# Altium schematic sheet ESP32.SchDoc(ESP32)
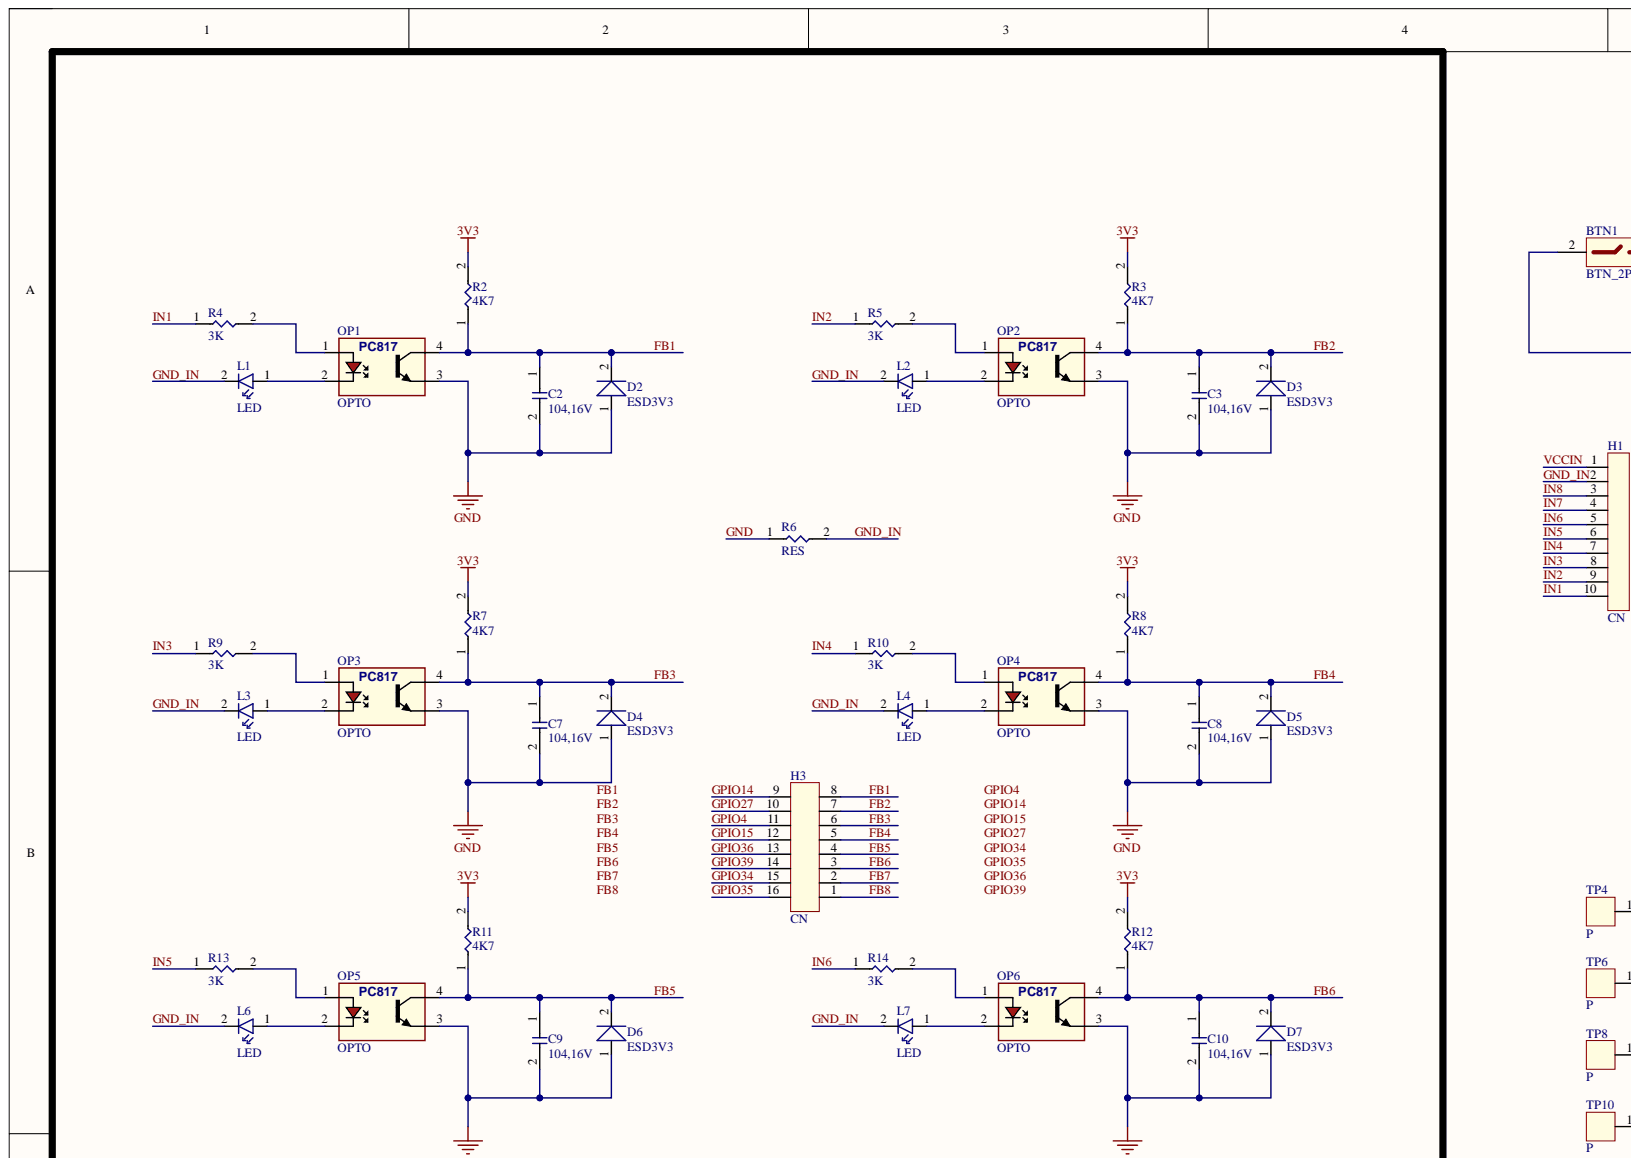
[diagram p0: ESP32.SchDoc, top left]
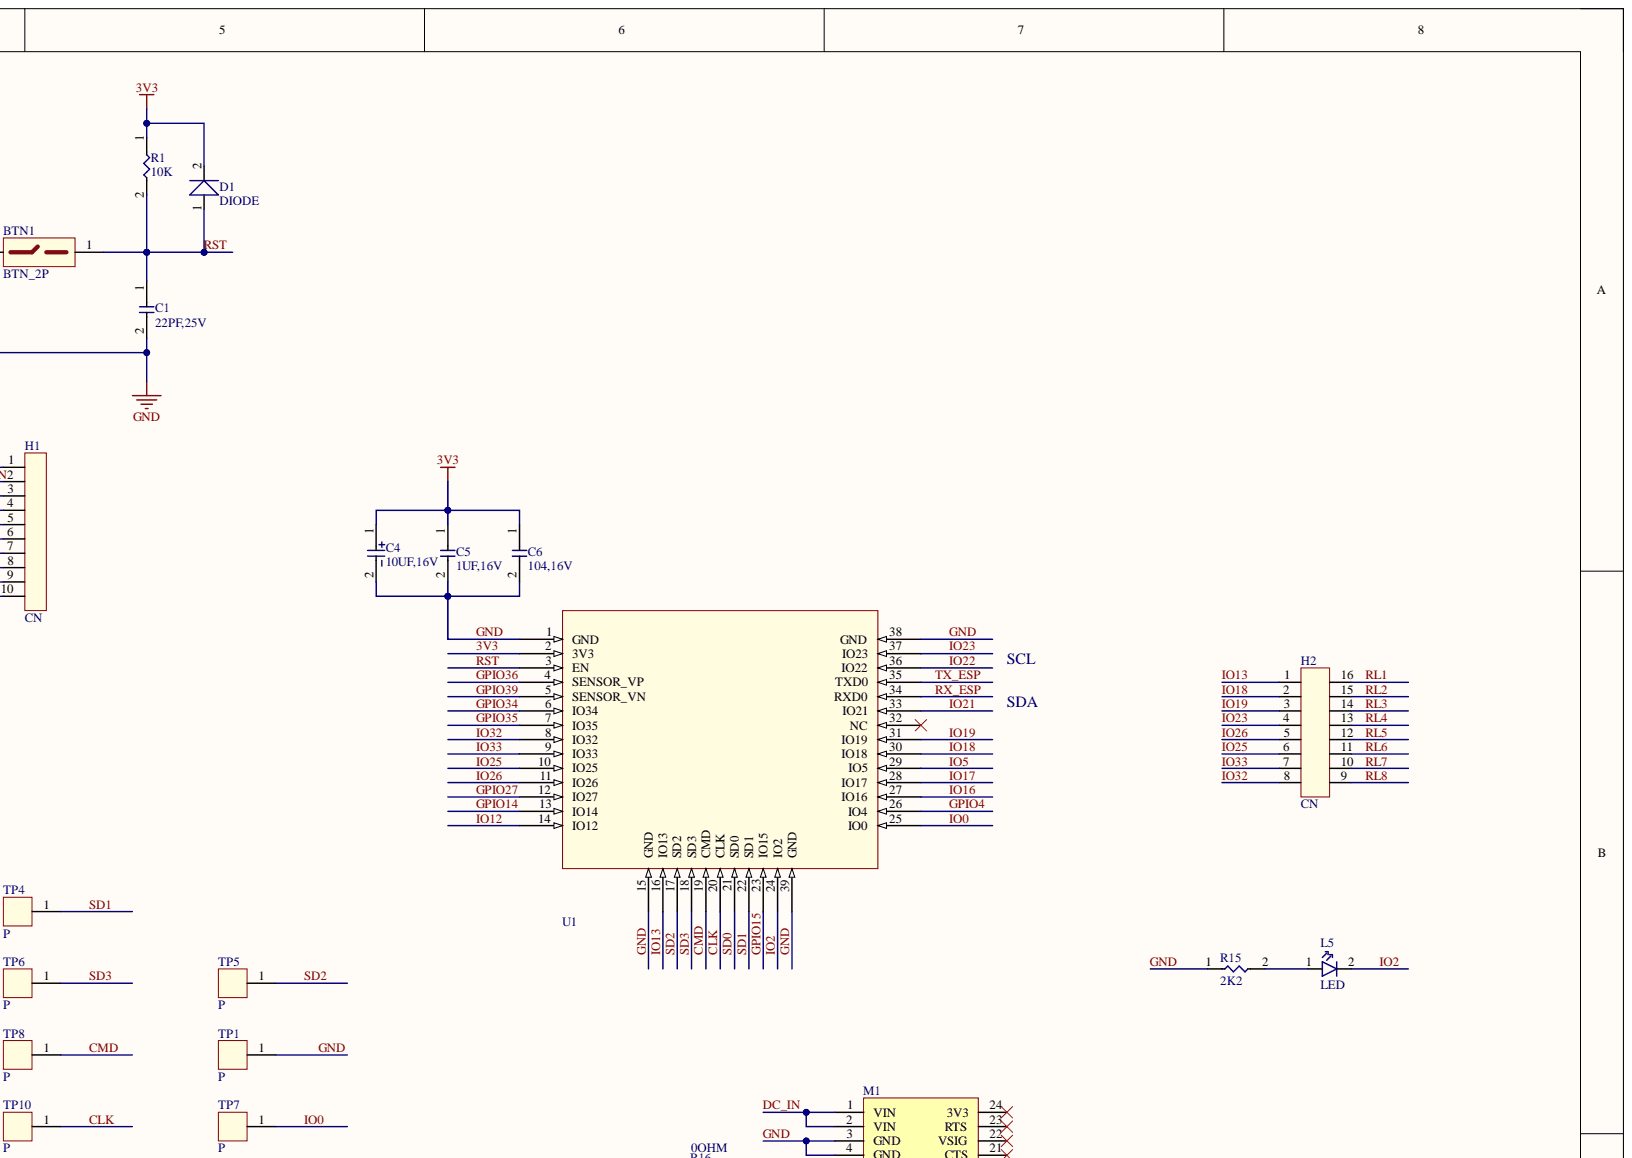
[diagram p1: ESP32.SchDoc, top right]
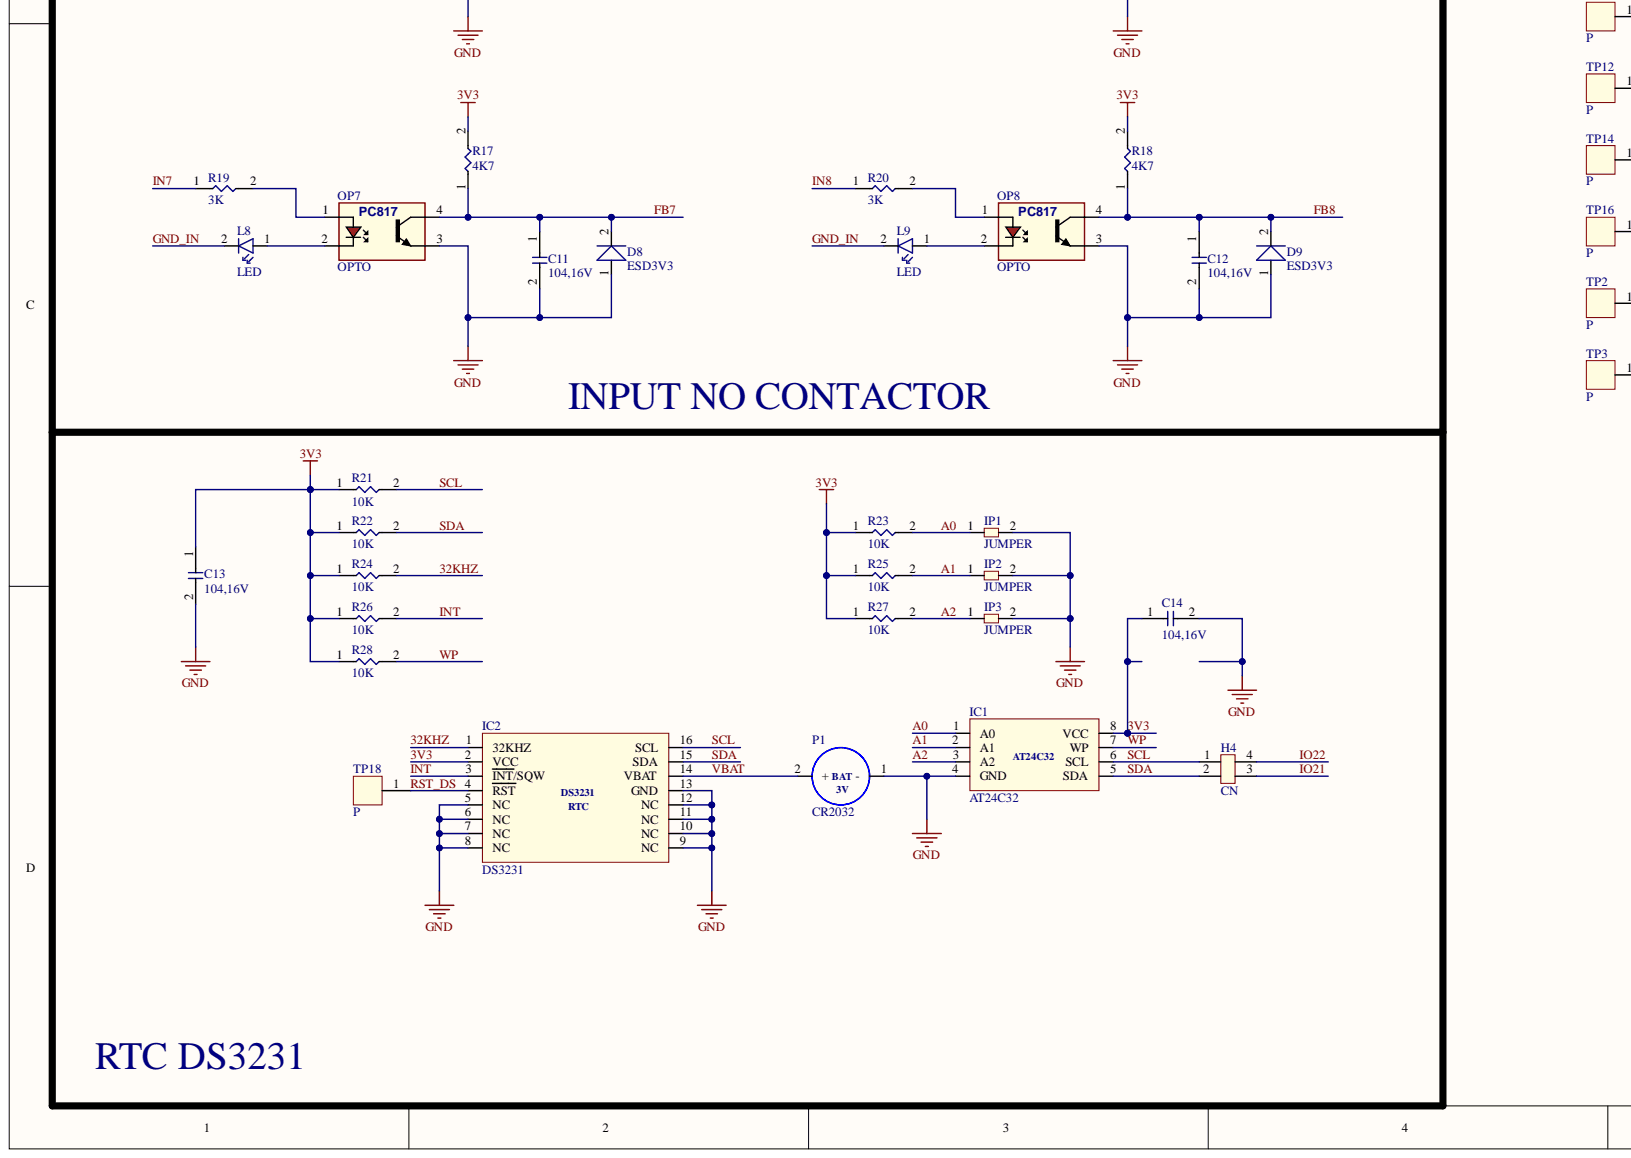
[diagram p2: ESP32.SchDoc, bottom left]
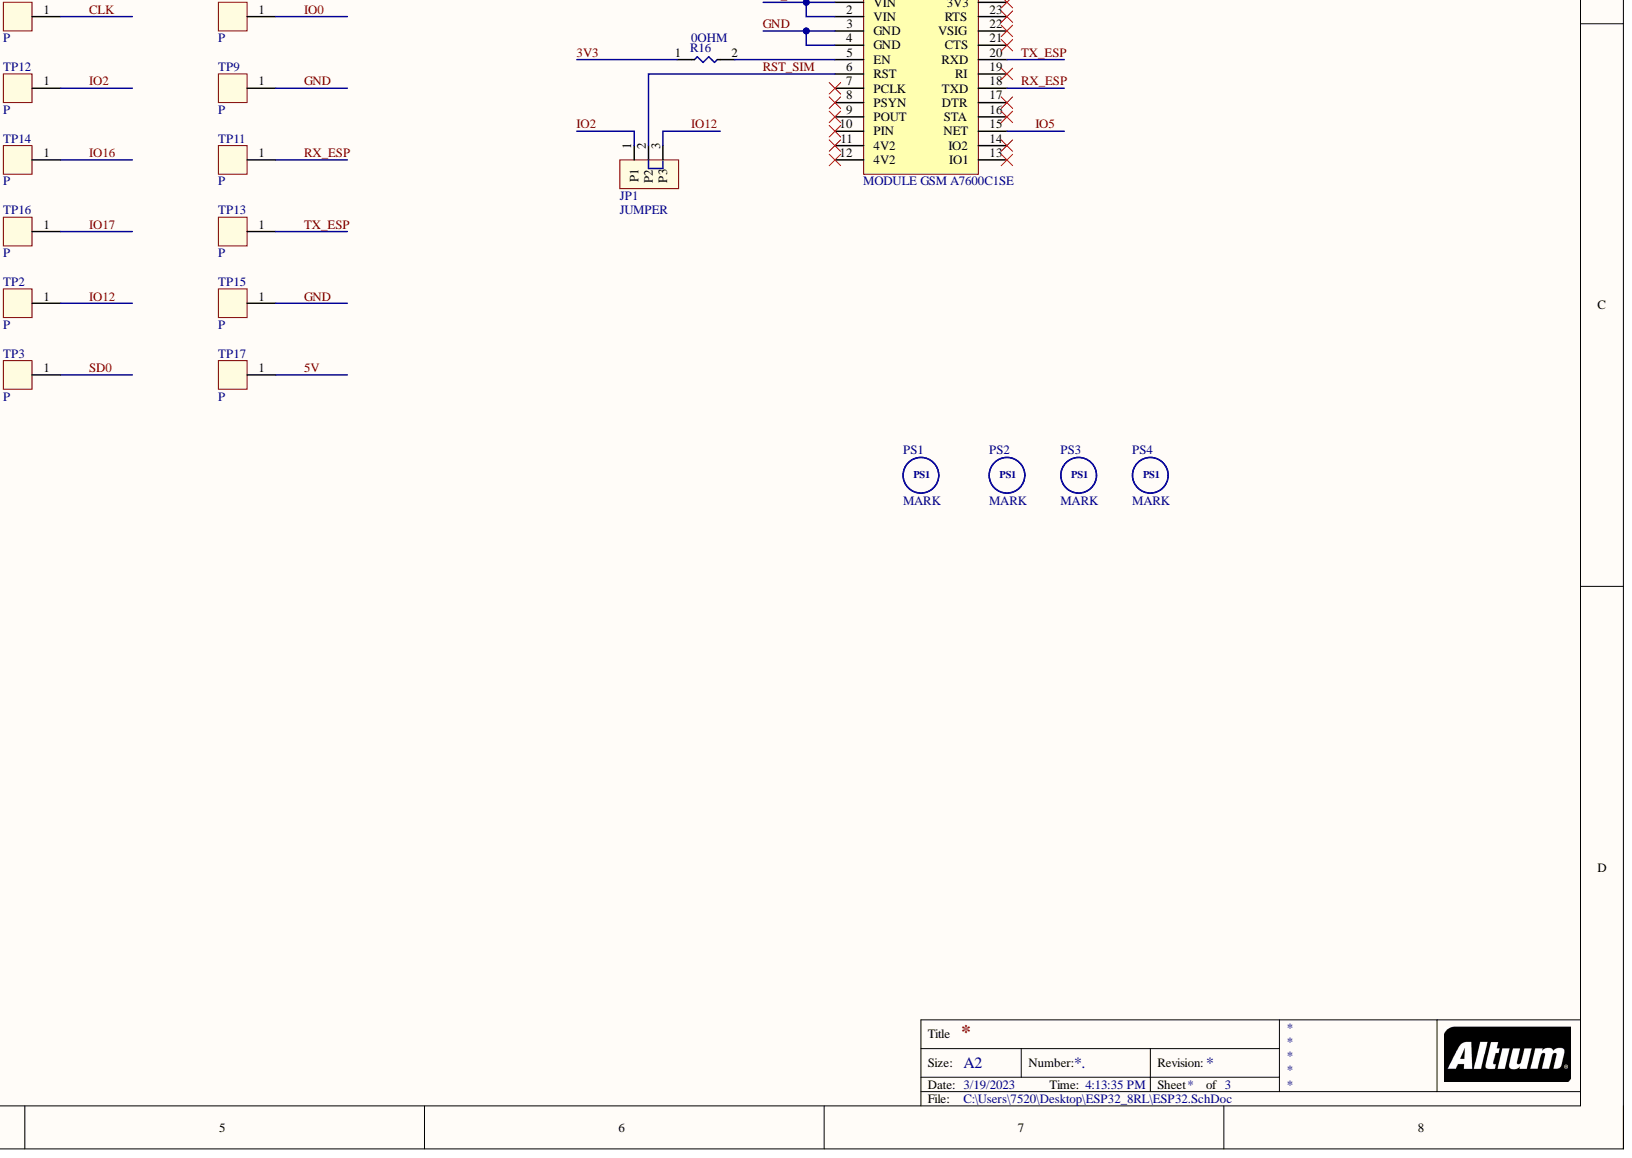
[diagram p3: ESP32.SchDoc, bottom right]

## Parts
BTN1  BTN_2P  [BTN_80X60X35]
C1  22PF,25V  [cap_smd_0603]
C2  104,16V  [cap_smd_0603]
C3  104,16V  [cap_smd_0603]
C4  10UF,16V  [CAP_TAN_B]
C5  1UF,16V  [cap_smd_0603]
C6  104,16V  [cap_smd_0603]
C7  104,16V  [cap_smd_0603]
C8  104,16V  [cap_smd_0603]
C9  104,16V  [cap_smd_0603]
C10  104,16V  [cap_smd_0603]
C11  104,16V  [cap_smd_0603]
C12  104,16V  [cap_smd_0603]
C13  104,16V  [cap_smd_0603]
C14  104,16V  [cap_smd_0603]
D1  DIODE  [DIODE_SMD_SOD_323]
D2  ESD3V3  [DIODE_SMD_SOD_323]
D3  ESD3V3  [DIODE_SMD_SOD_323]
D4  ESD3V3  [DIODE_SMD_SOD_323]
D5  ESD3V3  [DIODE_SMD_SOD_323]
D6  ESD3V3  [DIODE_SMD_SOD_323]
D7  ESD3V3  [DIODE_SMD_SOD_323]
D8  ESD3V3  [DIODE_SMD_SOD_323]
D9  ESD3V3  [DIODE_SMD_SOD_323]
H1  CN  [10P_35MM_TER]
H2  CN  [8X02P_T]
H3  CN  [8X02P_T]
H4  CN  [2X02P_T]
IC1  AT24C32  [AT24C32]
IC2  DS3231  [DS3231]
IP1  JUMPER  [JP]
IP2  JUMPER  [JP]
IP3  JUMPER  [JP]
JP1  JUMPER  [jp3_0603]
L1  LED  [led_0603_blue]
L2  LED  [led_0603_blue]
L3  LED  [led_0603_blue]
L4  LED  [led_0603_blue]
L5  LED  [LED_3MM_DIP]
L6  LED  [led_0603_blue]
L7  LED  [led_0603_blue]
L8  LED  [led_0603_blue]
L9  LED  [led_0603_blue]
M1  MODULE GSM A7600C1SE  [A7600C1SE]
OP1  OPTO  [PC817_SMD]
OP2  OPTO  [PC817_SMD]
OP3  OPTO  [PC817_SMD]
OP4  OPTO  [PC817_SMD]
OP5  OPTO  [PC817_SMD]
OP6  OPTO  [PC817_SMD]
OP7  OPTO  [PC817_SMD]
OP8  OPTO  [PC817_SMD]
P1  CR2032  [CR1220]
PS1  MARK  [ps1]
PS2  MARK  [ps1]
PS3  MARK  [ps1]
PS4  MARK  [ps1]
R1  10K  [0603_R_L]
R2  4K7  [0603_R_L]
R3  4K7  [0603_R_L]
R4  3K  [0805_R_L]
R5  3K  [0805_R_L]
R6  RES  [0805_R_L]
R7  4K7  [0603_R_L]
R8  4K7  [0603_R_L]
R9  3K  [0805_R_L]
R10  3K  [0805_R_L]
R11  4K7  [0603_R_L]
R12  4K7  [0603_R_L]
R13  3K  [0805_R_L]
R14  3K  [0805_R_L]
R15  2K2  [0603_R_L]
R16  0OHM  [0603_R_L]
R17  4K7  [0603_R_L]
R18  4K7  [0603_R_L]
R19  3K  [0805_R_L]
R20  3K  [0805_R_L]
R21  10K  [0603_R_L]
R22  10K  [0603_R_L]
R23  10K  [0603_R_L]
R24  10K  [0603_R_L]
R25  10K  [0603_R_L]
R26  10K  [0603_R_L]
R27  10K  [0603_R_L]
R28  10K  [0603_R_L]
TP1  P  [TP]
TP2  P  [TP]
TP3  P  [TP]
TP4  P  [TP]
TP5  P  [TP]
TP6  P  [TP]
TP7  P  [TP]
TP8  P  [TP]
TP9  P  [TP]
TP10  P  [TP]
TP11  P  [TP]
TP12  P  [TP]
TP13  P  [TP]
TP14  P  [TP]
TP15  P  [TP]
TP16  P  [TP]
TP17  P  [TP]
TP18  P  [TP]
U1  ESP32-WROOM-32  [ESP32-WROOM-32D]  (value not drawn)

## Nets
3V3: C4.1 C5.1 C6.1 C13.1 C14.1 D1.2 IC1.8(VCC) IC2.2(VCC) R1.1 R2.2 R3.2 R7.2 R8.2 R11.2 R12.2 R16.1 R17.2 R18.2 R21.1 R22.1 R23.1 R24.1 R25.1 R26.1 R27.1 R28.1 U1.2(3V3)
5V: TP17.1
32KHZ: IC2.1(32KHZ) R24.2
A0: IC1.1(A0) IP1.1 R23.2
A1: IC1.2(A1) IP2.1 R25.2
A2: IC1.3(A2) IP3.1 R27.2
CLK: TP10.1 U1.20(CLK)
CMD: TP8.1 U1.19(CMD)
DC_IN: M1.1(VIN) M1.2(VIN)
FB1: C2.1 D2.2 H3.8 OP1.4 R2.1
FB2: C3.1 D3.2 H3.7 OP2.4 R3.1
FB3: C7.1 D4.2 H3.6 OP3.4 R7.1
FB4: C8.1 D5.2 H3.5 OP4.4 R8.1
FB5: C9.1 D6.2 H3.4 OP5.4 R11.1
FB6: C10.1 D7.2 H3.3 OP6.4 R12.1
FB7: C11.1 D8.2 H3.2 OP7.4 R17.1
FB8: C12.1 D9.2 H3.1 OP8.4 R18.1
GND: BTN1.2 C1.2 C2.2 C3.2 C4.2 C5.2 C6.2 C7.2 C8.2 C9.2 C10.2 C11.2 C12.2 C13.2 C14.2 D2.1 D3.1 D4.1 D5.1 D6.1 D7.1 D8.1 D9.1 IC1.4(GND) IC2.5(NC) IC2.6(NC) IC2.7(NC) IC2.8(NC) IC2.9(NC) IC2.10(NC) IC2.11(NC) IC2.12(NC) IC2.13(GND) IP1.2 IP2.2 IP3.2 M1.3(GND) M1.4(GND) OP1.3 OP2.3 OP3.3 OP4.3 OP5.3 OP6.3 OP7.3 OP8.3 P1.1 R6.1 R15.1 TP1.1 TP9.1 TP15.1 U1.1(GND) U1.15(GND) U1.38(GND) U1.39(GND)
GND_IN: H1.2 L1.2 L2.2 L3.2 L4.2 L6.2 L7.2 L8.2 L9.2 R6.2
GPIO4: H3.11 U1.26(IO4)
GPIO14: H3.9 U1.13(IO14)
GPIO15: H3.12 U1.23(IO15)
GPIO27: H3.10 U1.12(IO27)
GPIO34: H3.15 U1.6(IO34)
GPIO35: H3.16 U1.7(IO35)
GPIO36: H3.13 U1.4(SENSOR_VP)
GPIO39: H3.14 U1.5(SENSOR_VN)
IN1: H1.10 R4.1
IN2: H1.9 R5.1
IN3: H1.8 R9.1
IN4: H1.7 R10.1
IN5: H1.6 R13.1
IN6: H1.5 R14.1
IN7: H1.4 R19.1
IN8: H1.3 R20.1
INT: IC2.3(INT/SQW) R26.2
IO0: TP7.1 U1.25(IO0)
IO2: JP1.1 L5.2 TP12.1 U1.24(IO2)
IO5: M1.15(NET) U1.29(IO5)
IO12: JP1.3 TP2.1 U1.14(IO12)
IO13: H2.1 U1.16(IO13)
IO16: TP14.1 U1.27(IO16)
IO17: TP16.1 U1.28(IO17)
IO18: H2.2 U1.30(IO18)
IO19: H2.3 U1.31(IO19)
IO21: H4.3 U1.33(IO21)
IO22: H4.4 U1.36(IO22)
IO23: H2.4 U1.37(IO23)
IO25: H2.6 U1.10(IO25)
IO26: H2.5 U1.11(IO26)
IO32: H2.8 U1.8(IO32)
IO33: H2.7 U1.9(IO33)
RL1: H2.16
RL2: H2.15
RL3: H2.14
RL4: H2.13
RL5: H2.12
RL6: H2.11
RL7: H2.10
RL8: H2.9
RST: BTN1.1 C1.1 D1.1 R1.2 U1.3(EN)
RST_DS: IC2.4(RST) TP18.1
RST_SIM: JP1.2 M1.6(RST)
RX_ESP: M1.18(TXD) TP11.1 U1.34(RXD0)
SCL: H4.1 IC1.6(SCL) IC2.16(SCL) R21.2
SD0: TP3.1 U1.21(SD0)
SD1: TP4.1 U1.22(SD1)
SD2: TP5.1 U1.17(SD2)
SD3: TP6.1 U1.18(SD3)
SDA: H4.2 IC1.5(SDA) IC2.15(SDA) R22.2
TX_ESP: M1.20(RXD) TP13.1 U1.35(TXD0)
VBAT: IC2.14(VBAT) P1.2
VCCIN: H1.1
WP: IC1.7(WP) R28.2
NetL1_1: L1.1 OP1.2
NetL2_1: L2.1 OP2.2
NetL3_1: L3.1 OP3.2
NetL4_1: L4.1 OP4.2
NetL5_1: L5.1 R15.2
NetL6_1: L6.1 OP5.2
NetL7_1: L7.1 OP6.2
NetL8_1: L8.1 OP7.2
NetL9_1: L9.1 OP8.2
NetM1_5: M1.5(EN) R16.2
NetOP1_1: OP1.1(PC817) R4.2
NetOP2_1: OP2.1(PC817) R5.2
NetOP3_1: OP3.1(PC817) R9.2
NetOP4_1: OP4.1(PC817) R10.2
NetOP5_1: OP5.1 R13.2
NetOP6_1: OP6.1 R14.2
NetOP7_1: OP7.1 R19.2
NetOP8_1: OP8.1 R20.2

Not connected: M1 7(PCLK) 8(PSYN) 9(POUT) 10(PIN) 11(4V2) 12(4V2) 13(IO1) 14(IO2) 16(STA) 17(DTR) 19(RI) 21(CTS) 22(VSIG) 23(RTS) 24(3V3); U1 32(NC)

## Library data of the parts
C1-C3 C5-C14 = CAPACITOR: Component Kind: Standard; Library Name: COMPONENT.IntLib
C4 = CAP_TAN: Component Kind: Standard; Library Name: COMPONENT.IntLib
D2-D9 = DIODE: Component Kind: Standard; Library Name: COMPONENT.IntLib
H1 = 10X01P: Component Kind: Standard; Library Name: COMPONENT.IntLib
H2 H3 = 8X02P: Component Kind: Standard; Library Name: COMPONENT.IntLib
H4 = 2x02p: Component Kind: Standard; Library Name: COMPONENT.IntLib
IP1-IP3 = JP_0805M: Component Kind: Standard; Library Name: COMPONENT.IntLib
JP1 = JP3_0603: Component Kind: Standard; Library Name: COMPONENT.IntLib
M1 = A7600C1SE: Component Kind: Standard; Library Name: wriless_module.IntLib
OP1-OP8 = PC817: Component Kind: Standard; Library Name: COMPONENT.IntLib
PS1-PS4 = PS1: Component Kind: Standard; Library Name: COMPONENT.IntLib
R1-R5 R7-R28 = RESISTOR: Component Kind: Standard; Description: resistor; Library Name: COMPONENT.IntLib
R6 = RESISTOR: TYPE DIP & SMD; Component Kind: Standard; Library Name: COMPONENT.IntLib
TP1-TP18 = TP: Component Kind: Standard; Library Name: COMPONENT.IntLib
U1 = ESP32-WROOM-32: ESP32; Manufacturer: Espressif Inc.; Reviewer: Fenrrich; Component Kind: Standard; ComponentLink1Description: Datasheet; ComponentLink1URL: https://www.espressif.com/sites/default/files/documentation/esp32-wroom-32_datasheet_en.pdf; Part Number: ESP32-WROOM-32; Data Reviewer: 03-May-2019; Library Name: wriless_module.IntLib; Publisher: Cynetik Group
BTN1 = BTN_2P: Component Kind: Standard; Library Name: COMPONENT.IntLib
D1 = DIODE: Component Kind: Standard; Library Name: COMPONENT.IntLib
IC1 = AT24C32: Component Kind: Standard; Library Name: TYPE_B.IntLib
IC2 = DS3231: Component Kind: Standard; Library Name: TYPE_B.IntLib
L1-L9 = LED: Component Kind: Standard; Library Name: COMPONENT.IntLib
P1 = CR2032: Component Kind: Standard; Library Name: COMPONENT.IntLib
